# Altium schematic sheet GAP_MEM_CSI2.SchDoc
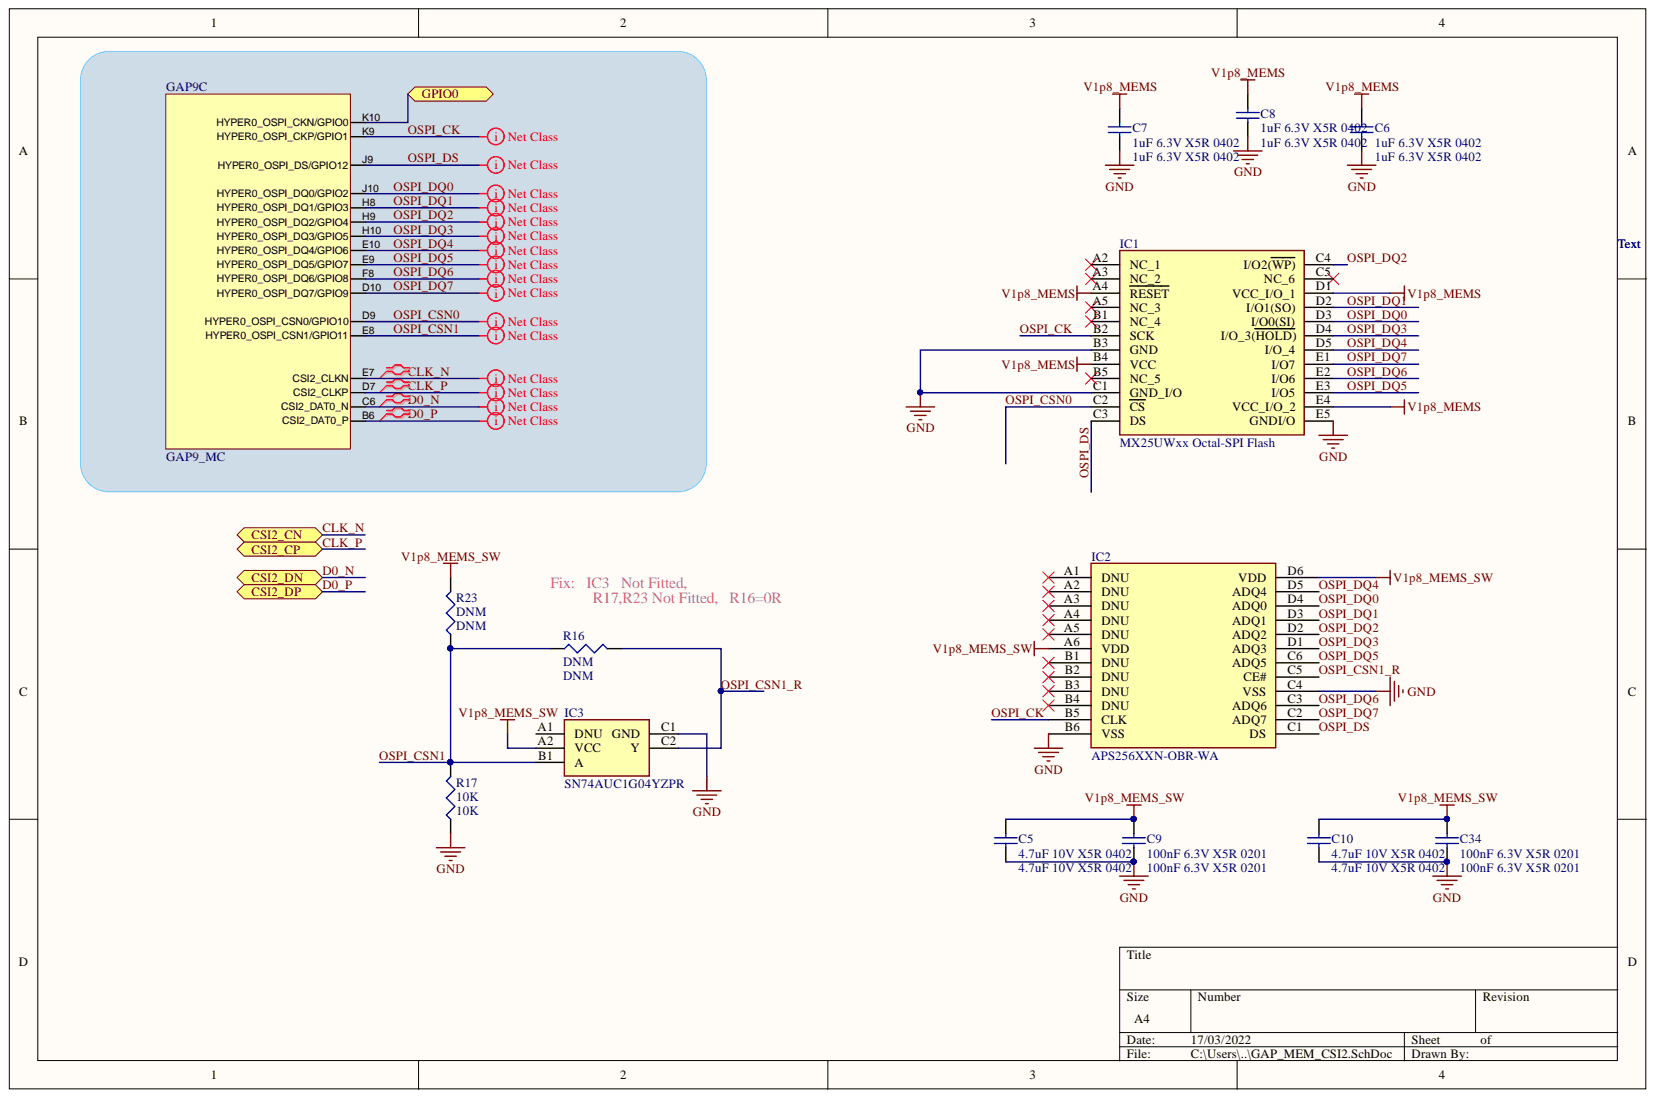
[diagram p0: GAP_MEM_CSI2.SchDoc]

## Parts
C5  4.7uF 10V X5R 0402  [0402]
C6  1uF 6.3V X5R 0402  [0402]
C7  1uF 6.3V X5R 0402  [0402]
C8  1uF 6.3V X5R 0402  [0402]
C9  100nF 6.3V X5R 0201  [CAPC0603X33N]
C10  4.7uF 10V X5R 0402  [0402]
C34  100nF 6.3V X5R 0201  [CAPC0603X33N]
GAP9  GAP9_MC  [GAP9]  (part C)
IC1  MX25UWxx Octal-SPI Flash  [BGA24C100P5X5_600X800X100]
IC2  APS256XXN-OBR-WA  [WLCSP24]
IC3  SN74AUC1G04YZPR  [BGA5C50P2X3_89X139X50]
R16  DNM  [0402]
R17  10K  [0402]
R23  DNM  [0402]

## Ports
CSI2_CN, CSI2_CP, CSI2_DN, CSI2_DP, GPIO0

## Nets
GND: C5.1 C6.1 C7.1 C8.1 C9.1 C10.1 C34.1 IC1.B3(GND) IC1.C1(GND_I/O) IC1.E5(GNDI/O) IC2.B6(VSS) IC2.C4(VSS) IC3.C1(GND) R17.1
V1p8_MEMS: C6.2 C7.2 C8.2 IC1.A4(RESET) IC1.B4(VCC) IC1.D1(VCC_I/O_1) IC1.E4(VCC_I/O_2)
V1p8_MEMS_SW: C5.2 C9.2 C10.2 C34.2 IC2.A6(VDD) IC2.D6(VDD) IC3.A2(VCC) R23.2
CLK_N: GAP9.E7(CSI2_CLKN) ; port CSI2_CN
CLK_P: GAP9.D7(CSI2_CLKP) ; port CSI2_CP
D0_N: GAP9.C6(CSI2_DAT0_N) ; port CSI2_DN
D0_P: GAP9.B6(CSI2_DAT0_P) ; port CSI2_DP
GPIO0: GAP9.K10(HYPER0_OSPI_CKN/GPIO0) ; port GPIO0
OSPI_CK: GAP9.K9(HYPER0_OSPI_CKP/GPIO1) IC1.B2(SCK) IC2.B5(CLK)
OSPI_CSN0: GAP9.D9(HYPER0_OSPI_CSN0/GPIO10) IC1.C2(CS)
OSPI_CSN1: GAP9.E8(HYPER0_OSPI_CSN1/GPIO11) IC3.B1(A) R16.1 R17.2 R23.1
OSPI_CSN1_R: IC2.C5(CE#) IC3.C2(Y) R16.2
OSPI_DQ0: GAP9.J10(HYPER0_OSPI_DQ0/GPIO2) IC1.D3(I/O0(SI)) IC2.D4(ADQ0)
OSPI_DQ1: GAP9.H8(HYPER0_OSPI_DQ1/GPIO3) IC1.D2(I/O1(SO)) IC2.D3(ADQ1)
OSPI_DQ2: GAP9.H9(HYPER0_OSPI_DQ2/GPIO4) IC1.C4(I/O2(WP)) IC2.D2(ADQ2)
OSPI_DQ3: GAP9.H10(HYPER0_OSPI_DQ3/GPIO5) IC1.D4(I/O_3(HOLD)) IC2.D1(ADQ3)
OSPI_DQ4: GAP9.E10(HYPER0_OSPI_DQ4/GPIO6) IC1.D5(I/O_4) IC2.D5(ADQ4)
OSPI_DQ5: GAP9.E9(HYPER0_OSPI_DQ5/GPIO7) IC1.E3(I/O5) IC2.C6(ADQ5)
OSPI_DQ6: GAP9.F8(HYPER0_OSPI_DQ6/GPIO8) IC1.E2(I/O6) IC2.C3(ADQ6)
OSPI_DQ7: GAP9.D10(HYPER0_OSPI_DQ7/GPIO9) IC1.E1(I/O7) IC2.C2(ADQ7)
OSPI_DS: GAP9.J9(HYPER0_OSPI_DS/GPIO12) IC1.C3(DS) IC2.C1(DS)

Not connected: IC1 A2(NC_1) A3(NC_2) A5(NC_3) B1(NC_4) B5(NC_5) C5(NC_6); IC2 A1(DNU) A2(DNU) A3(DNU) A4(DNU) A5(DNU) B1(DNU) B2(DNU) B3(DNU) B4(DNU); IC3 A1(DNU)

## Library data of the parts
C5 C9 C10 C34 = Cap Semi: Capacitor (Semiconductor SIM Model); Component Kind: Standard; LatestRevisionDate: 29-May-2009; LatestRevisionNote: IPC-7351 Footprint Added.; Library Name: Miscellaneous Devices.IntLib; PackageDescription: Chip Capacitor; PackageReference: C1206; Published: 8-Jun-2000; Signal Integrity: Cap Semi; Simulation: CAP; Publisher: Altium Limited
  C5 C10: Value: 4.7uF 10V X5R 0402
  C9 C34: Value: 100nF 6.3V X5R 0201
C6-C8 = Cap Semi: =Comment; Component Kind: Standard; Description: 1uF 6.3V X5R 0402; LatestRevisionDate: 29-May-2009; LatestRevisionNote: IPC-7351 Footprint Added.; Library Name: Miscellaneous Devices.IntLib; PackageDescription: Chip Capacitor; PackageReference: C1206; Published: 8-Jun-2000; Signal Integrity: Cap Semi; Simulation: CAP; Value: 1uF 6.3V X5R 0402; Publisher: Altium Limited
IC1 = ATXP032-CCUE-T: Integrated Circuit; Manufacturer_Name: Adesto Technologies; Arrow Part Number: ATXP032-CCUE-T; Arrow Price/Stock: https://www.arrow.com/en/products/atxp032-ccue-t/adesto-technologies-corporation-inc; Component Kind: Standard; Datasheet Link: https://www.adestotech.com/wp-content/uploads/ATXP032_21Sep2016-SUMMARY---.pdf; Height: 1mm; Manufacturer_Part_Number: ATXP032-CCUE-T; Mouser Part Number: 988-ATXP032-CCUE-T; Mouser Price/Stock: https://www.mouser.co.uk/ProductDetail/Adesto-Technologies/ATXP032-CCUE-T?qs=vdi0iO8H4N3ckJDbjUS2%2Fw%3D%3D
IC2 = APS256XXN-OBR-WA: Integrated Circuit; Component Kind: Standard; Library Name: Schlib1.SchLib
IC3 = SN74AUC1G04YZPR: Integrated Circuit; Manufacturer_Name: Texas Instruments; Component Kind: Standard; Datasheet Link: http://www.ti.com/lit/gpn/sn74auc1g04; Height: 0.5mm; Library Name: SamacSys.SchLib; Manufacturer_Part_Number: SN74AUC1G04YZPR; Mouser Part Number: 595-SN74AUC1G04YZPR; Mouser Price/Stock: http://www.mouser.com/Search/ProductDetail.aspx?qs=eXyjQBh9UFipX0kLM9SL5Q%3d%3d
R16 R17 R23 = Res3: Resistor; Component Kind: Standard; LatestRevisionDate: 29-May-2009; LatestRevisionNote: IPC-7351 Footprint Added.; Library Name: Miscellaneous Devices.IntLib; PackageDescription: Chip Resistor; PackageReference: J1-0603; Published: 8-Jun-2000; Signal Integrity: Res; Simulation: RESISTOR; Publisher: Altium Limited
  R16 R23: Value: DNM
  R17: Value: 10K
GAP9 = GAP9_MC: Component Kind: Standard; Library Name: GAP9.SchLib
